# Altium schematic sheet SW3.SchDoc
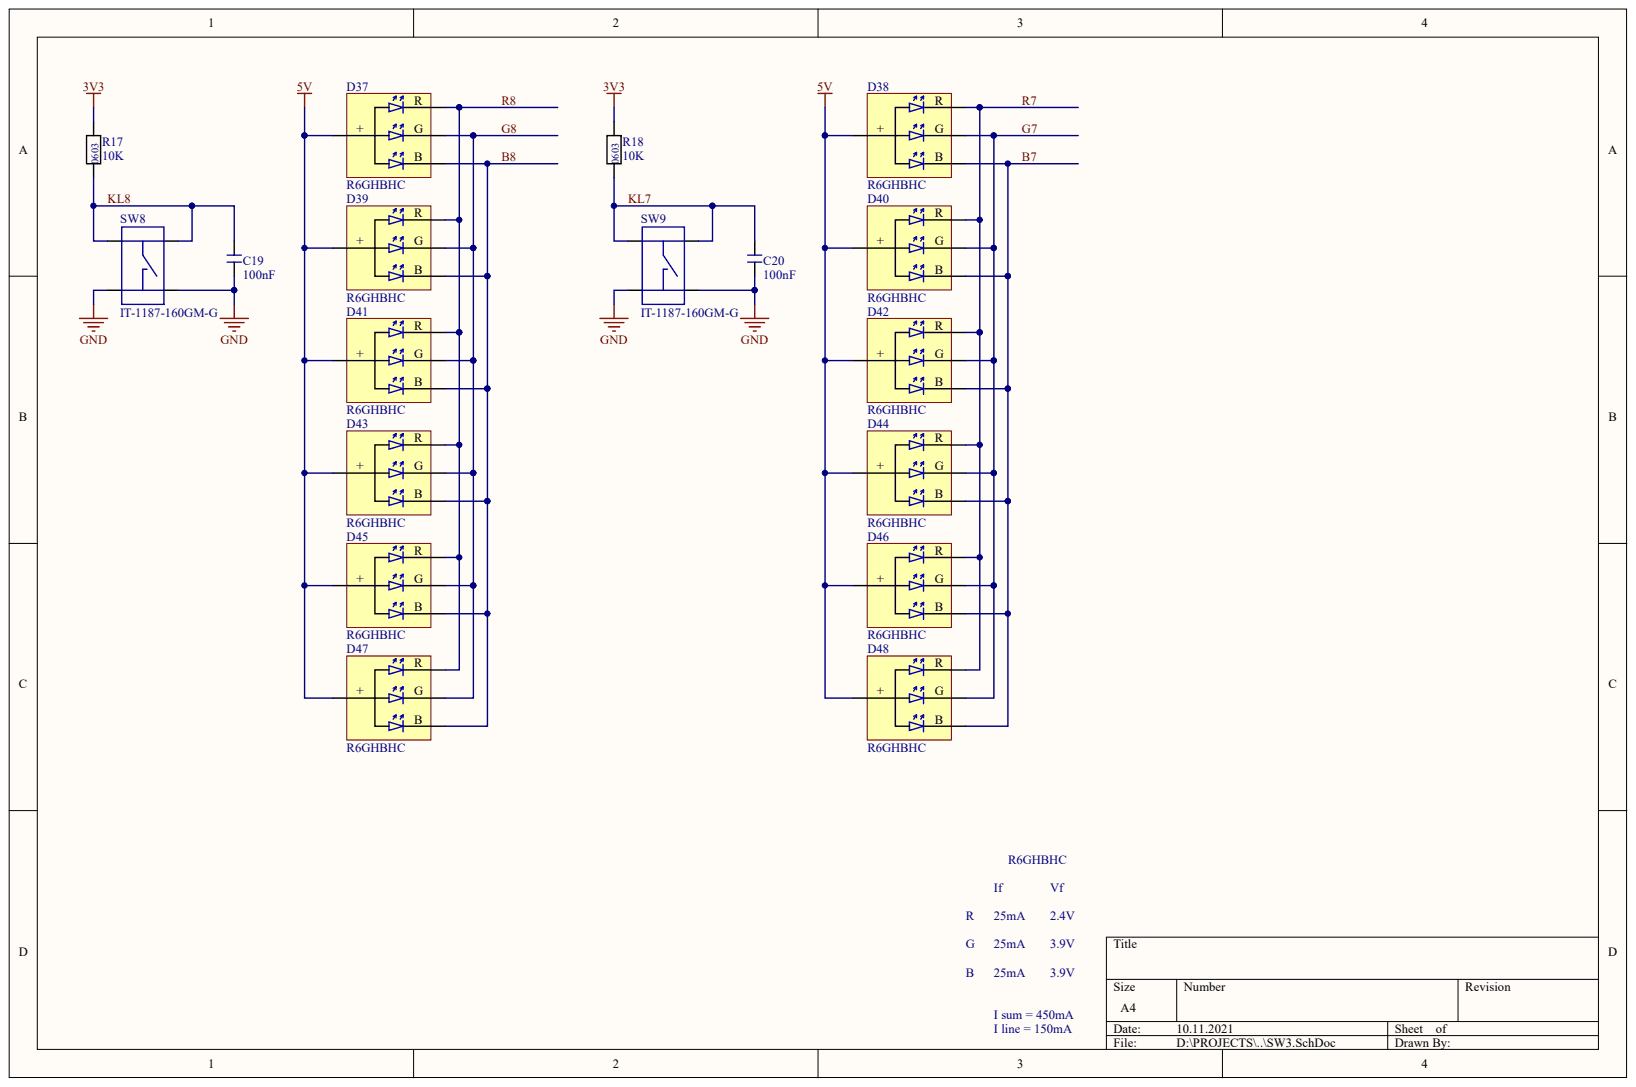
[diagram p0: SW3.SchDoc]

## Parts
C19  100nF
C20  100nF
D37  R6GHBHC
D38  R6GHBHC
D39  R6GHBHC
D40  R6GHBHC
D41  R6GHBHC
D42  R6GHBHC
D43  R6GHBHC
D44  R6GHBHC
D45  R6GHBHC
D46  R6GHBHC
D47  R6GHBHC
D48  R6GHBHC
R17  10K
R18  10K
SW8  IT-1187-160GM-G
SW9  IT-1187-160GM-G

## Nets
3V3: R17.2 R18.2
5V: D37.+ D38.+ D39.+ D40.+ D41.+ D42.+ D43.+ D44.+ D45.+ D46.+ D47.+ D48.+
GND: C19.1 C20.1 SW8.3 SW8.4 SW9.3 SW9.4
B7: D38.B D40.B D42.B D44.B D46.B D48.B
B8: D37.B D39.B D41.B D43.B D45.B D47.B
G7: D38.G D40.G D42.G D44.G D46.G D48.G
G8: D37.G D39.G D41.G D43.G D45.G D47.G
KL7: C20.2 R18.1 SW9.1 SW9.2
KL8: C19.2 R17.1 SW8.1 SW8.2
R7: D38.R D40.R D42.R D44.R D46.R D48.R
R8: D37.R D39.R D41.R D43.R D45.R D47.R
